# Altium schematic sheet LPB100.SchDoc
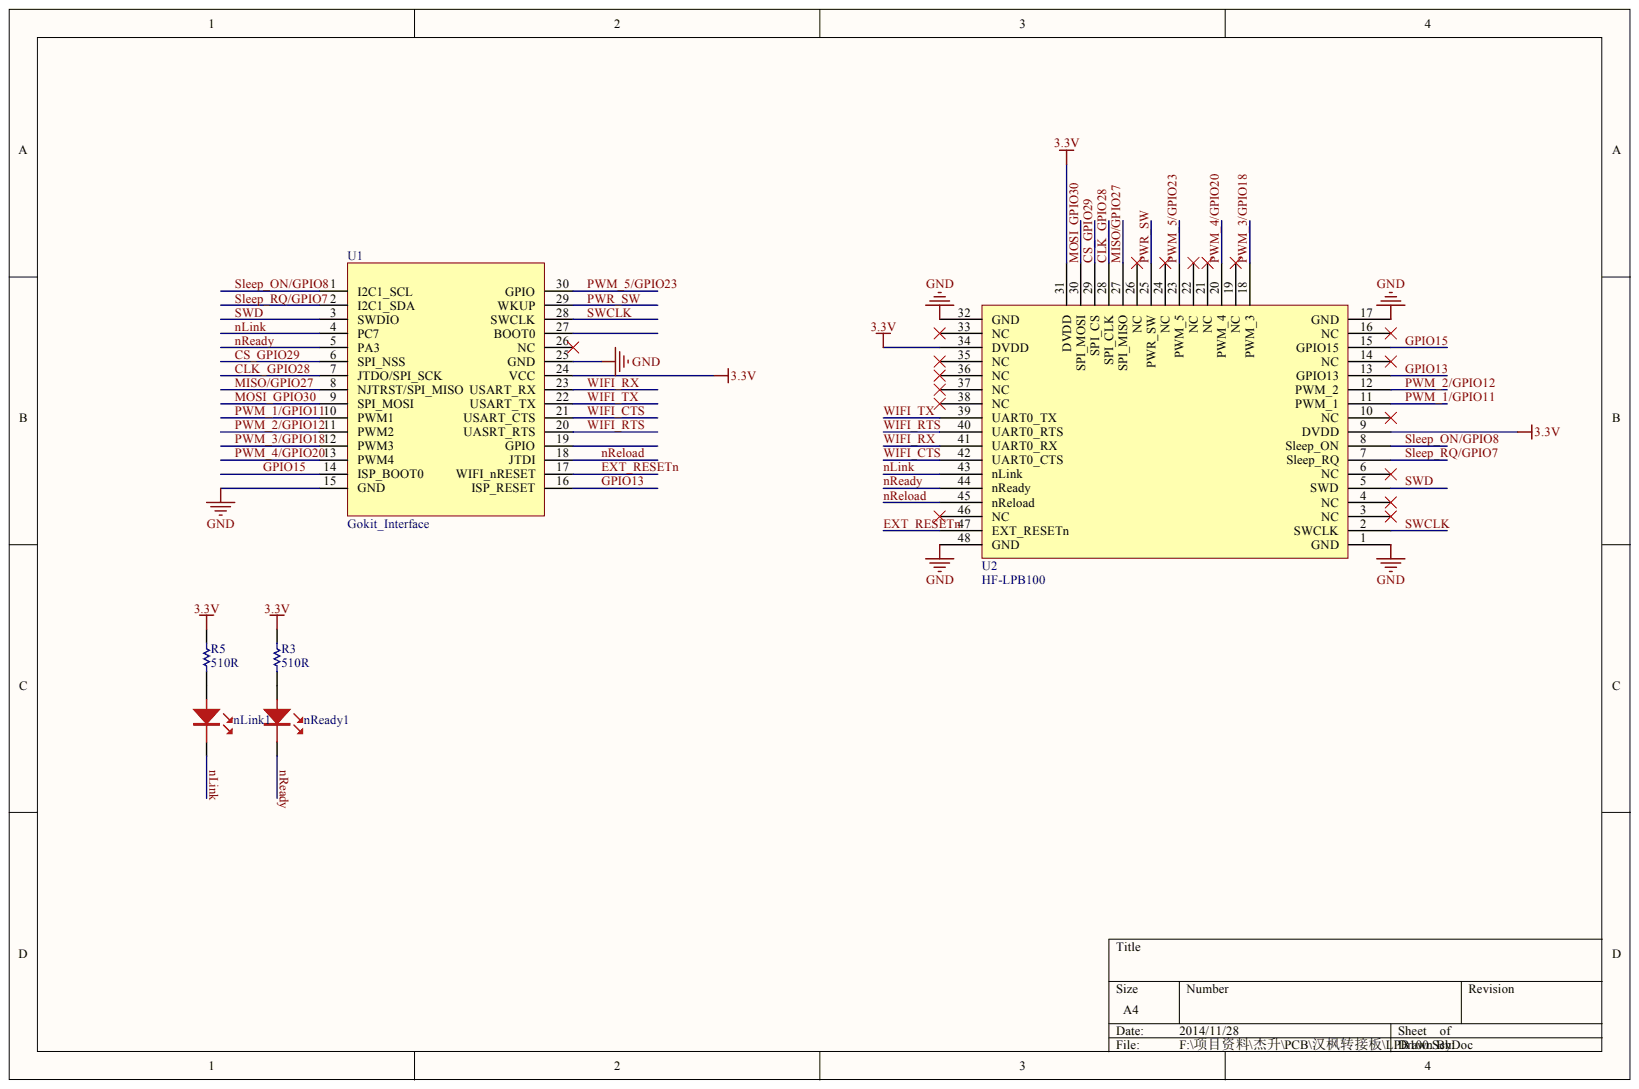
[diagram p0: LPB100.SchDoc]

## Parts
nLink1  LED_0805  [0805_LED]  (value not drawn)
nReady1  LED_0805  [0805_LED]  (value not drawn)
R3  510R  [0603]
R5  510R  [0603]
U1  Gokit_Interface  [EMW3162-1]
U2  HF-LPB100  [HF-LPB100]

## Nets
3.3V: R3.2 R5.2 U1.24(VCC) U2.9(DVDD) U2.31(DVDD) U2.34(DVDD)
GND: U1.15(GND) U1.25(GND) U2.1(GND) U2.17(GND) U2.32(GND) U2.48(GND)
CLK_GPIO28: U1.7(JTDO/SPI_SCK) U2.28(SPI_CLK)
CS_GPIO29: U1.6(SPI_NSS) U2.29(SPI_CS)
EXT_RESETN: U1.17(WIFI_nRESET) U2.47(EXT_RESETn)
GPIO13: U1.16(ISP_RESET) U2.13(GPIO13)
GPIO15: U1.14(ISP_BOOT0) U2.15(GPIO15)
MISO/GPIO27: U1.8(NJTRST/SPI_MISO) U2.27(SPI_MISO)
MOSI_GPIO30: U1.9(SPI_MOSI) U2.30(SPI_MOSI)
NLINK: nLink1.2 U1.4(PC7) U2.43(nLink)
NREADY: nReady1.2 U1.5(PA3) U2.44(nReady)
NRELOAD: U1.18(JTDI) U2.45(nReload)
PWM_1/GPIO11: U1.10(PWM1) U2.11(PWM_1)
PWM_2/GPIO12: U1.11(PWM2) U2.12(PWM_2)
PWM_3/GPIO18: U1.12(PWM3) U2.18(PWM_3)
PWM_4/GPIO20: U1.13(PWM4) U2.20(PWM_4)
PWM_5/GPIO23: U1.30(GPIO) U2.23(PWM_5)
PWR_SW: U1.29(WKUP) U2.25(PWR_SW)
SLEEP_ON/GPIO8: U1.1(I2C1_SCL) U2.8(Sleep_ON)
SLEEP_RQ/GPIO7: U1.2(I2C1_SDA) U2.7(Sleep_RQ)
SWCLK: U1.28(SWCLK) U2.2(SWCLK)
SWD: U1.3(SWDIO) U2.5(SWD)
WIFI_CTS: U1.21(USART_CTS) U2.42(UART0_CTS)
WIFI_RTS: U1.20(UASRT_RTS) U2.40(UART0_RTS)
WIFI_RX: U1.23(USART_RX) U2.41(UART0_RX)
WIFI_TX: U1.22(USART_TX) U2.39(UART0_TX)
NetnLink1_1: nLink1.1 R5.1
NetnReady1_1: nReady1.1 R3.1

Not connected: U1 19(GPIO) 26(NC) 27(BOOT0); U2 3(NC) 4(NC) 6(NC) 10(NC) 14(NC) 16(NC) 19(NC) 21(NC) 22(NC) 24(NC) 26(NC) 33(NC) 35(NC) 36(NC) 37(NC) 38(NC) 46(NC)

## Library data of the parts
R3 R5 = Res1: Resistor; Value: 1K; Component Kind: Standard; LatestRevisionDate: 17-Jul-2002; LatestRevisionNote: Re-released for DXP Platform.; Library Name: zz.SchLib; PackageReference: AXIAL-0.3; Published: 8-Jun-2000; Publisher: Altium Limited
U1 = Gokit_Interface: EMW3162; Component Kind: Standard; Library Name: WS_protel_lib.SchLib
nLink1 nReady1 = LED_0805: Component Kind: Standard; Library Name: zz.SchLib
U2 = HF-LPB100: Component Kind: Standard; Library Name: WS_protel_lib.SchLib
